# Altium schematic sheet Mikrokrmilnik, RF-detektor.SchDoc
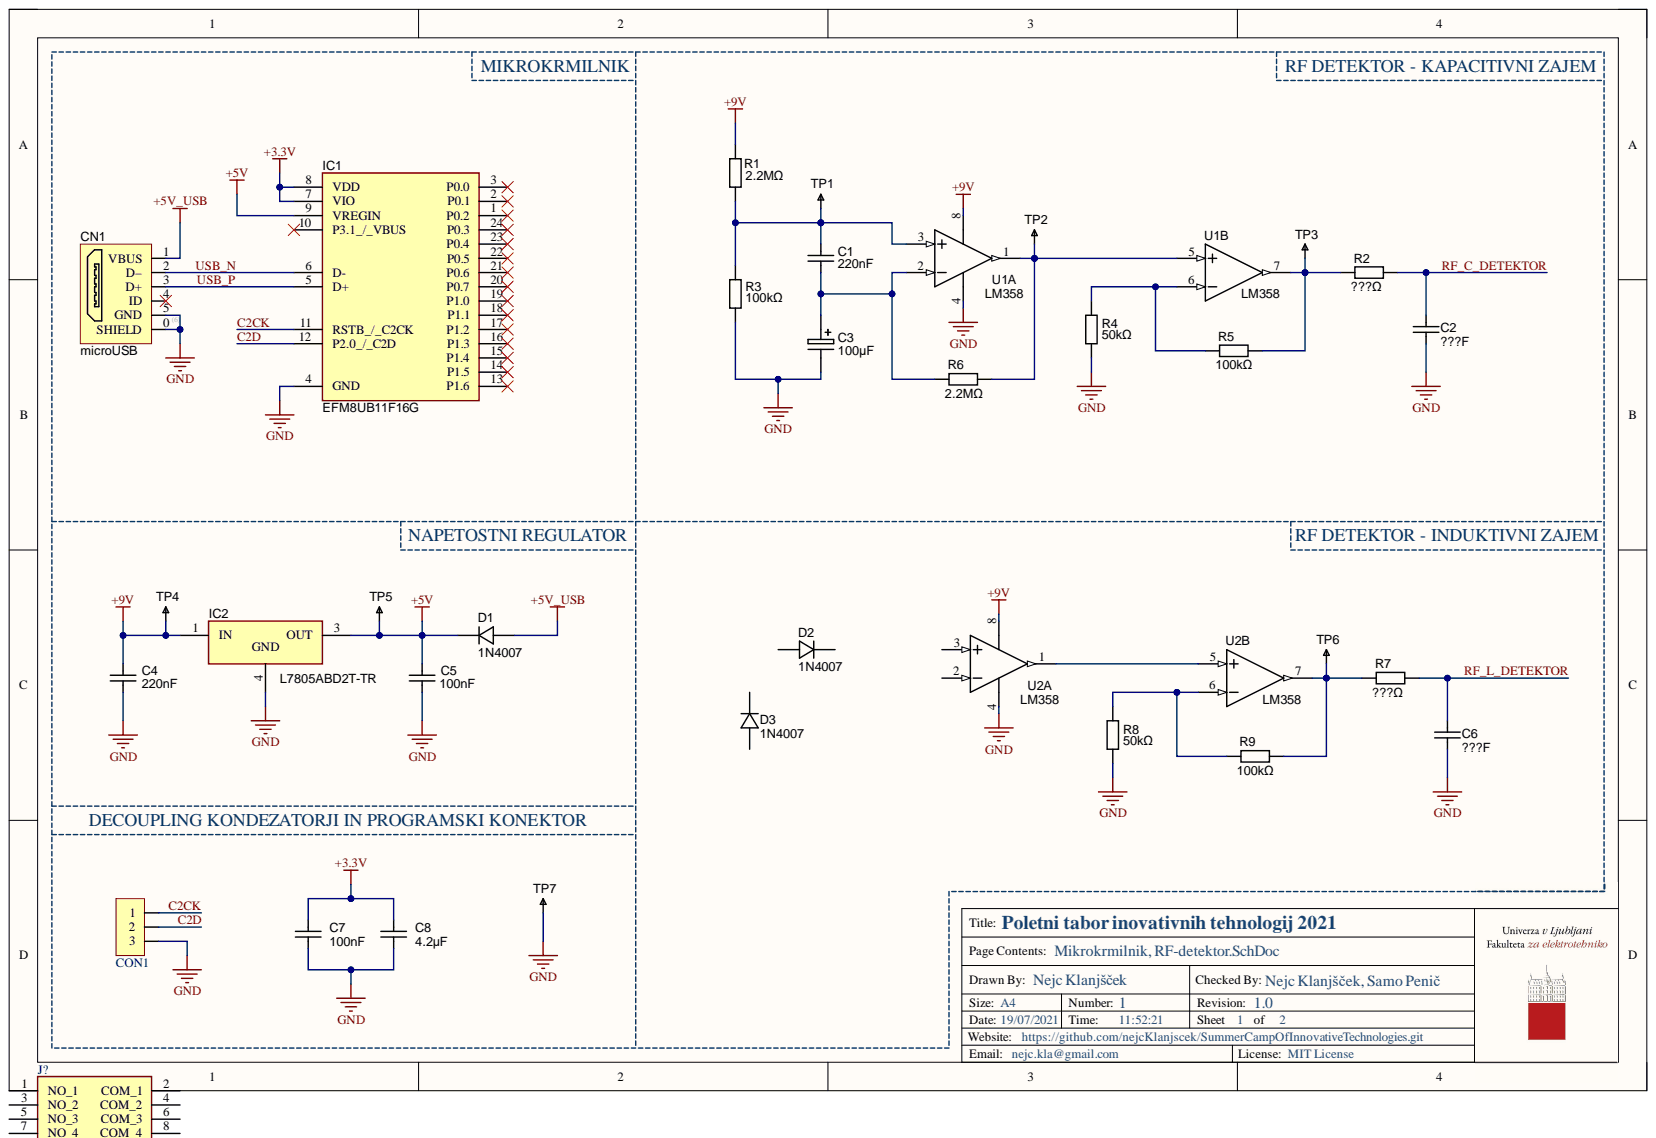
[diagram p0: Mikrokrmilnik, RF-detektor.SchDoc]

## Parts
C1  value 220nF  [B32520C0104J000]  (hidden comment: approved sub OK)
C2  value ???F  [B32520C0104J000]  (hidden comment: approved sub OK)
C3  100μF  [CAPE_5x11x2LS]
C4  value 220nF  [CAPC3216N]  (hidden comment: approved sub OK)
C5  value 100nF  [CAPC3216N]  (hidden comment: approved sub OK)
C6  value ???F  [B32520C0104J000]  (hidden comment: approved sub OK)
C7  value 100nF  [CAPC3216N]  (hidden comment: approved sub OK)
C8  value 4.2μF  [CAPC3216N]  (hidden comment: approved sub OK)
CN1  microUSB  [micro-USB-HRS-ZX62D-B-5PA8]
CON1  H1x3  [H1x3]  (value not drawn)
D1  1N4007  [DO-41]
D2  1N4007  [DO-41]
D3  1N4007  [DO-41]
IC1  EFM8UB11F16G  [SOP64P600X175-24N]
IC2  L7805ABD2T-TR  [D2PAK-STB35NF10T4]
R1  value 2.2MΩ  [RESTH_AXIAL-0.4]  (hidden comment: Carbon Film)
R2  value ???Ω  [RESTH_AXIAL-0.4]  (hidden comment: Carbon Film)
R3  value 100kΩ  [RESTH_AXIAL-0.4]  (hidden comment: Carbon Film)
R4  value 50kΩ  [RESTH_AXIAL-0.4]  (hidden comment: Carbon Film)
R5  value 100kΩ  [RESTH_AXIAL-0.4]  (hidden comment: Carbon Film)
R6  value 2.2MΩ  [RESTH_AXIAL-0.4]  (hidden comment: Carbon Film)
R7  value ???Ω  [RESTH_AXIAL-0.4]  (hidden comment: Carbon Film)
R8  value 50kΩ  [RESTH_AXIAL-0.4]  (hidden comment: Carbon Film)
R9  value 100kΩ  [RESTH_AXIAL-0.4]  (hidden comment: Carbon Film)
TP1  TESTPOINT  [TP-R60]  (value not drawn)  (no BOM)
TP2  TESTPOINT  [TP-R60]  (value not drawn)  (no BOM)
TP3  TESTPOINT  [TP-R60]  (value not drawn)  (no BOM)
TP4  TESTPOINT  [TP-R60]  (value not drawn)  (no BOM)
TP5  TESTPOINT  [TP-R60]  (value not drawn)  (no BOM)
TP6  TESTPOINT  [TP-R60]  (value not drawn)  (no BOM)
TP7  TESTPOINT  [TP-R60]  (value not drawn)  (no BOM)
U1  LM358  [DIP8-300]  (parts A,B)
U2  LM358  [DIP8-300]  (parts A,B)

## Nets
+3.3V: C7.1 C8.1 IC1.7(VIO) IC1.8(VDD)
+5V: C5.1 D1.K IC1.9(VREGIN) IC2.3 TP5.1
+5V_USB: CN1.1(VBUS) D1.A
+9V: C4.1 IC2.1 R1.2 TP4.1 U1.8 U2.8
GND: C2.1 C3.2 C4.2 C5.2 C6.1 C7.2 C8.2 CN1.5(GND) CN1.6(SHIELD) CON1.3 IC1.4(GND) IC2.4 R3.1 R4.1 R8.1 TP7.1 U1.4 U2.4
C2CK: CON1.1 IC1.11(RSTB_/_C2CK)
C2D: CON1.2 IC1.12(P2.0_/_C2D)
RF_C_DETEKTOR: C2.2 R2.2
RF_L_DETEKTOR: C6.2 R7.2
USB_N: CN1.2(D–) IC1.6(D-)
USB_P: CN1.3(D+) IC1.5(D+)
NetC1_1: C1.1 R1.1 R3.2 TP1.1 U1.3
NetC1_2: C1.2 C3.1 R6.1 U1.2
NetR2_1: R2.1 R5.2 TP3.1 U1.7
NetR4_2: R4.2 R5.1 U1.6
NetR6_2: R6.2 TP2.1 U1.1 U1.5
NetR7_1: R7.1 R9.2 TP6.1 U2.7
NetR8_2: R8.2 R9.1 U2.6
NetU2_1: U2.1 U2.5

Not connected: CN1 4(ID); D2 A K; D3 A K; IC1 1(P0.2) 2(P0.1) 3(P0.0) 10(P3.1_/_VBUS) 13(P1.6) 14(P1.5) 15(P1.4) 16(P1.3) 17(P1.2) 18(P1.1) 19(P1.0) 20(P0.7) 21(P0.6) 22(P0.5) 23(P0.4) 24(P0.3); U2 2 3

## Library data of the parts
C1 = CAPC_220n_B32520: Capacitor THD Cer 220nF 10% 100V X7R B32520; Manufacturer 1: TDK Corporation; Manufacturer Part Number 1: C1608X7R1C224K; Ratings: 16V; X7R; Component Kind: Standard; Package / Case: 0603 (1608 metric); Supplier 1: Digi-Key; Supplier Part Number 1: 445-1318-1-ND; Tolerance: ±10%; Library Name: Nejc Klanjšček.IntLib
C2 C6 = CAPC_220n_B32520: Capacitor THD Cer ???F 10% 100V X7R B32520; Manufacturer 1: TDK Corporation; Manufacturer Part Number 1: C1608X7R1C224K; Ratings: 16V; X7R; Component Kind: Standard; Package / Case: 0603 (1608 metric); Supplier 1: Digi-Key; Supplier Part Number 1: 445-1318-1-ND; Tolerance: ±10%; Library Name: Nejc Klanjšček.IntLib
C3 = CAPT_10u_5mm_63V: Component Kind: Standard; Library Name: Nejc Klanjšček.IntLib
C4 = CAPC_10u_1206_10V: Capacitor SMD Cer 220nF 10% 10V X5R 1206; Manufacturer 1: KEMET; Manufacturer Part Number 1: C1206C106K8PACTU; Material: ceramic; Ratings: 10V; X5R; Component Kind: Standard; Package / Case: 1206 (3216 metric); Supplier 1: Digi-Key; Supplier Part Number 1: C1206C106K8PACTU-ND; Tolerance: ±10%; Library Name: Nejc Klanjšček.IntLib
C5 C7 = CAPC_10u_1206_10V: Capacitor SMD Cer 100nF 10% 10V X5R 1206; Manufacturer 1: KEMET; Manufacturer Part Number 1: C1206C106K8PACTU; Material: ceramic; Ratings: 10V; X5R; Component Kind: Standard; Package / Case: 1206 (3216 metric); Supplier 1: Digi-Key; Supplier Part Number 1: C1206C106K8PACTU-ND; Tolerance: ±10%; Library Name: Nejc Klanjšček.IntLib
C8 = CAPC_10u_1206_10V: Capacitor SMD Cer 4.2uF 10% 10V X5R 1206; Manufacturer 1: KEMET; Manufacturer Part Number 1: C1206C106K8PACTU; Material: ceramic; Ratings: 10V; X5R; Component Kind: Standard; Package / Case: 1206 (3216 metric); Supplier 1: Digi-Key; Supplier Part Number 1: C1206C106K8PACTU-ND; Tolerance: ±10%; Library Name: Nejc Klanjšček.IntLib
CN1 = microUSB-B-socket (HIROSE ZX62D-B-5PA8): micro USB-B socket (HIROSE ZX62D-B-5PA8); Component Kind: Standard; ComponentLink1Description: http://www.farnell.com/datasheets/1693470.pdf; ComponentLink1URL: http://www.farnell.com/datasheets/1693470.pdf; Supplier 1: Farnell; Supplier Part Number 1: 2300438; Library Name: Nejc Klanjšček.IntLib
CON1 = H1x3: Header 1x8 (male); Component Kind: Standard; Library Name: Nejc Klanjšček.IntLib
D1-D3 = DIODE_1N4007_1000V_1.0A_DO-41: Diode 1N4007 1A 1000V THD; Manufacturer 1: Diodes Inc; Manufacturer Part Number 1: 1N4007-T; Diode Type: Standard; Ratings: 100V; 1.5A; Value: Vf = 1.15V @ 1.5A (Max); Component Kind: Standard; Mounting Type: Through Hole; Package / Case: DO-41; Library Name: Nejc Klanjšček.IntLib
IC1 = EFM8UB11F16G-C-QSOP24: Integrated Circuit; Manufacturer_Name: Silicon Labs; Arrow Part Number: EFM8UB11F16G-C-QSOP24; Arrow Price/Stock: https://www.arrow.com/en/products/efm8ub11f16g-c-qsop24/silicon-labs; Component Kind: Standard; Datasheet Link: http://www.mouser.com/ds/2/368/EFM8UB1_DataSheet-793165.pdf; Height: 1.75mm; Manufacturer_Part_Number: EFM8UB11F16G-C-QSOP24; Mouser Part Number: 634-8UB11F16GCQSOP24; Mouser Price/Stock: https://www.mouser.co.uk/ProductDetail/Silicon-Labs/EFM8UB11F16G-C-QSOP24?qs=h6RIvS%252BzmlfRbNYtg60NqQ%3D%3D; Library Name: Nejc Klanjšček.IntLib
IC2 = L7805ABD2T-TR: Integrated Circuit; Manufacturer_Name: STMicroelectronics; Arrow Part Number: L7805ABD2T-TR; Arrow Price/Stock: https://www.arrow.com/en/products/l7805abd2t-tr/stmicroelectronics; Component Kind: Standard; Datasheet Link: https://www.st.com/resource/en/datasheet/l78.pdf; Manufacturer_Part_Number: L7805ABD2T-TR; Mouser Part Number: 511-L7805ABD2T-TR; Mouser Price/Stock: https://www.mouser.co.uk/ProductDetail/STMicroelectronics/L7805ABD2T-TR?qs=LL%252BsqOK9SqOQ%2FfZD5ZsNqA%3D%3D; Library Name: Nejc Klanjšček.IntLib
R1 R6 = RES_12K_Axial_0.25W_5%: Resistor THD Axial 2.2MΩ 0.25W 5% Carbon Film; Manufacturer 1: Yageo; Manufacturer Part Number 1: CFR-25JB-12K; Ratings: 1/4W; Component Kind: Standard; Package / Case: Axial; Supplier 1: Digi-Key; Supplier Part Number 1: 12KQBK-ND; Tolerance: ±5%; Library Name: Nejc Klanjšček.IntLib
R2 R7 = RES_12K_Axial_0.25W_5%: Resistor THD Axial ???Ω 0.25W 5% Carbon Film; Manufacturer 1: Yageo; Manufacturer Part Number 1: CFR-25JB-12K; Ratings: 1/4W; Component Kind: Standard; Package / Case: Axial; Supplier 1: Digi-Key; Supplier Part Number 1: 12KQBK-ND; Tolerance: ±5%; Library Name: Nejc Klanjšček.IntLib
R3 R5 R9 = RES_12K_Axial_0.25W_5%: Resistor THD Axial 100kΩ 0.25W 5% Carbon Film; Manufacturer 1: Yageo; Manufacturer Part Number 1: CFR-25JB-12K; Ratings: 1/4W; Component Kind: Standard; Package / Case: Axial; Supplier 1: Digi-Key; Supplier Part Number 1: 12KQBK-ND; Tolerance: ±5%; Library Name: Nejc Klanjšček.IntLib
R4 R8 = RES_12K_Axial_0.25W_5%: Resistor THD Axial 50kΩ 0.25W 5% Carbon Film; Manufacturer 1: Yageo; Manufacturer Part Number 1: CFR-25JB-12K; Ratings: 1/4W; Component Kind: Standard; Package / Case: Axial; Supplier 1: Digi-Key; Supplier Part Number 1: 12KQBK-ND; Tolerance: ±5%; Library Name: Nejc Klanjšček.IntLib
TP1-TP7 = TESTPOINT: SMD test pin; Library Name: Nejc Klanjšček.IntLib
U1 U2 = IC_Op-Amp_LM358: IC THD Op Amp Precision Micropower SOIC-8; Manufacturer 1: Linear Technology; Manufacturer Part Number 1: LT1077IS8#PBF; Gain Bandwidth Product: 230kHz; Voltage - Supply: 2.2 V ~ 30 V, ±1.1 V ~ 15 V; Component Kind: Standard; Package / Case: 8-SOIC (0.154", 3.90mm Width); Supplier 1: Digi-Key; Supplier Part Number 1: LT1077IS8#PBF-ND; Library Name: Nejc Klanjšček.IntLib
